# Altium schematic sheet 12 HDMI RX.SchDoc
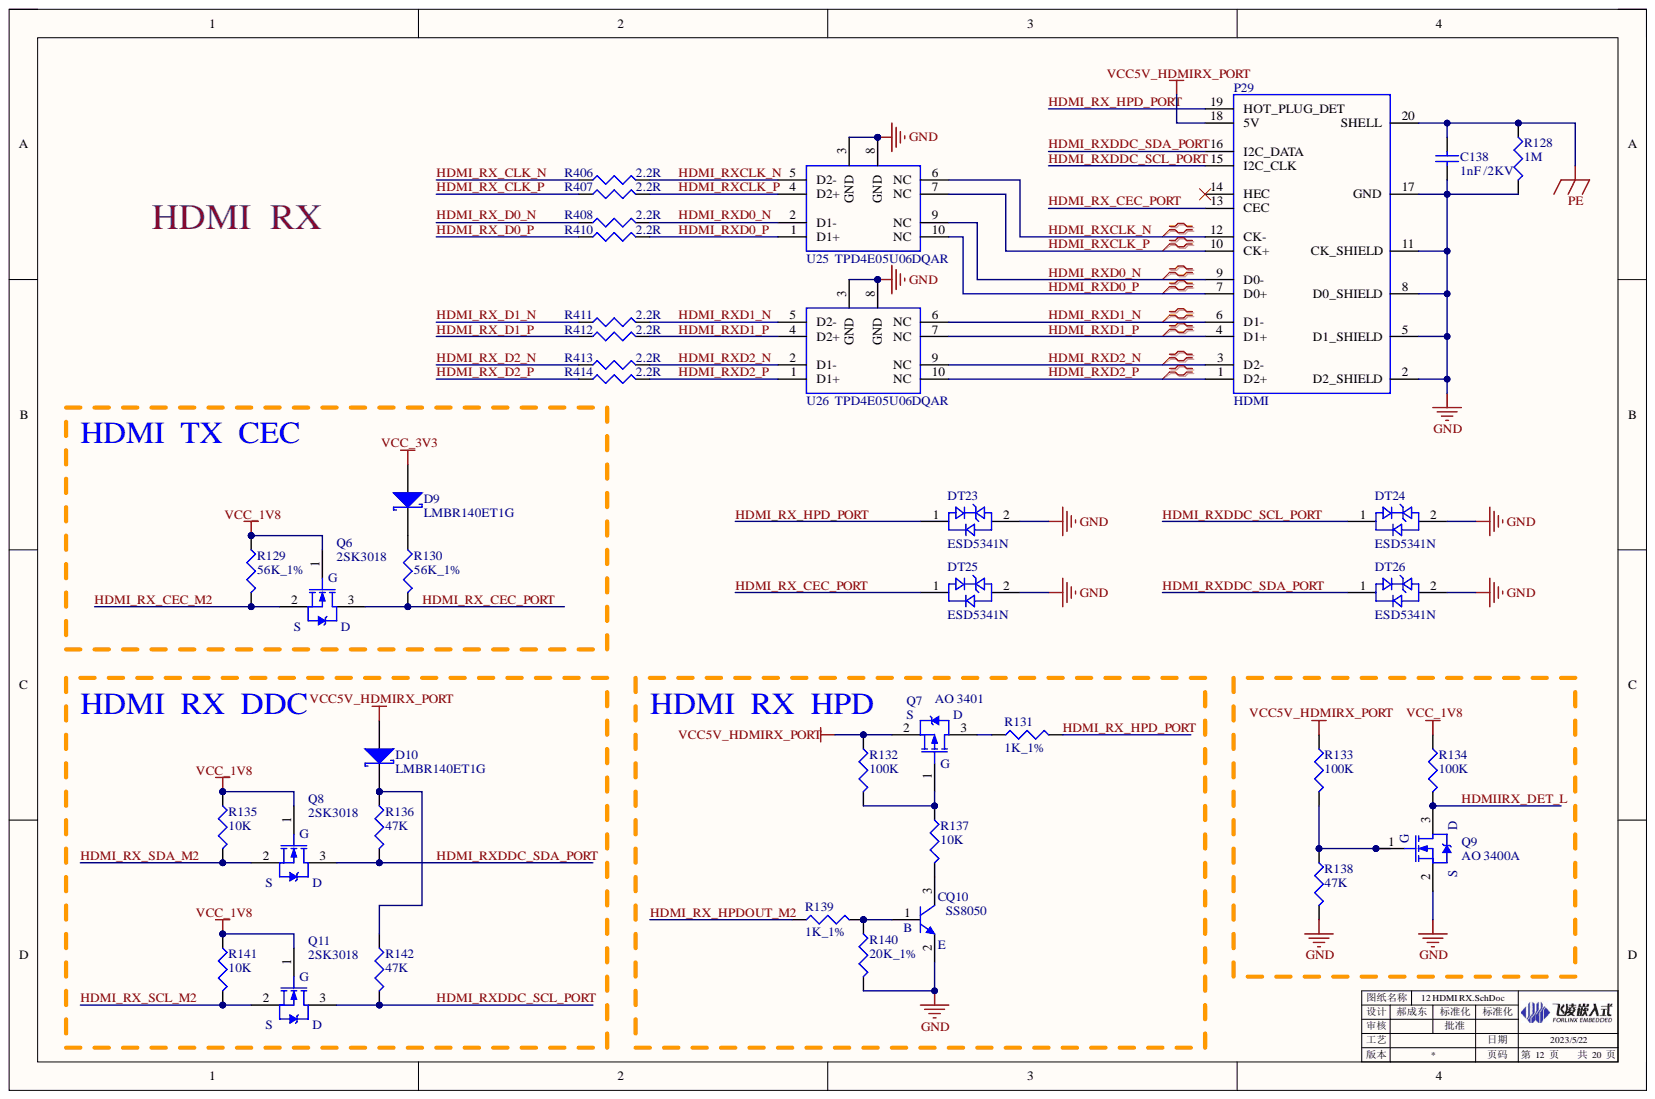
[diagram p0: 12 HDMI RX.SchDoc]

## Parts
C138  1nF /2KV  [1206C]
D9  LMBR140ET1G  [SOD_323]
D10  LMBR140ET1G  [SOD_323]
DT23  ESD5341N  [ESD5341N]
DT24  ESD5341N  [ESD5341N]
DT25  ESD5341N  [ESD5341N]
DT26  ESD5341N  [ESD5341N]
P29  HDMI  [HDMI]
Q6  2SK3018  [SOT323]
Q7  AO 3401  [SOT_233_W]
Q8  2SK3018  [SOT323]
Q9  AO 3400A  [SOT_233_W]
Q10  SS8050  [SOT_233_N]
Q11  2SK3018  [SOT323]
R128  1M  [1206R]
R129  56K_1%  [0402R]
R130  56K_1%  [0402R]
R131  1K_1%  [0402R]
R132  100K  [0402R]
R133  100K  [0402R]
R134  100K  [0402R]
R135  10K  [0402R]
R136  47K  [0402R]
R137  10K  [0402R]
R138  47K  [0402R]
R139  1K_1%  [0402R]
R140  20K_1%  [0402R]
R141  10K  [0402R]
R142  47K  [0402R]
R406  2.2R  [0201R]
R407  2.2R  [0201R]
R408  2.2R  [0201R]
R410  2.2R  [0201R]
R411  2.2R  [0201R]
R412  2.2R  [0201R]
R413  2.2R  [0201R]
R414  2.2R  [0201R]
U25  TPD4E05U06DQAR  [USON-10]
U26  TPD4E05U06DQAR  [USON-10]

## Nets
GND: C138.1 DT23.2 DT24.2 DT25.2 DT26.2 P29.2(D2_SHIELD) P29.5(D1_SHIELD) P29.8(D0_SHIELD) P29.11(CK_SHIELD) P29.17(GND) Q9.2 Q10.2 R128.2 R138.2 R140.2 U25.3(GND) U25.8(GND) U26.3(GND) U26.8(GND)
PE: C138.2 P29.20(SHELL) R128.1
VCC5V_HDMIRX_PORT: D10.1 P29.18(5V) Q7.2 R132.1 R133.1
VCC_1V8: Q6.1 Q8.1 Q11.1 R129.1 R134.1 R135.1 R141.1
VCC_3V3: D9.1
HDMI_RX_CEC_M2: Q6.2 R129.2
HDMI_RX_CEC_PORT: DT25.1 P29.13(CEC) Q6.3 R130.2
HDMI_RX_CLK_N: R406.1
HDMI_RX_CLK_P: R407.1
HDMI_RX_D0_N: R408.1
HDMI_RX_D0_P: R410.1
HDMI_RX_D1_N: R411.1
HDMI_RX_D1_P: R412.1
HDMI_RX_D2_N: R413.1
HDMI_RX_D2_P: R414.1
HDMI_RX_HPD_PORT: DT23.1 P29.19(HOT_PLUG_DET) R131.2
HDMI_RX_HPDOUT_M2: R139.1
HDMI_RX_SCL_M2: Q11.2 R141.2
HDMI_RX_SDA_M2: Q8.2 R135.2
HDMI_RXCLK_N: P29.12(CK-) R406.2 U25.5(D2-) U25.6(NC)
HDMI_RXCLK_P: P29.10(CK+) R407.2 U25.4(D2+) U25.7(NC)
HDMI_RXD0_N: P29.9(D0-) R408.2 U25.2(D1-) U25.9(NC)
HDMI_RXD0_P: P29.7(D0+) R410.2 U25.1(D1+) U25.10(NC)
HDMI_RXD1_N: P29.6(D1-) R411.2 U26.5(D2-) U26.6(NC)
HDMI_RXD1_P: P29.4(D1+) R412.2 U26.4(D2+) U26.7(NC)
HDMI_RXD2_N: P29.3(D2-) R413.2 U26.2(D1-) U26.9(NC)
HDMI_RXD2_P: P29.1(D2+) R414.2 U26.1(D1+) U26.10(NC)
HDMI_RXDDC_SCL_PORT: DT24.1 P29.15(I2C_CLK) Q11.3 R142.2
HDMI_RXDDC_SDA_PORT: DT26.1 P29.16(I2C_DATA) Q8.3 R136.2
HDMIIRX_DET_L: Q9.3 R134.2
NetD9_2: D9.2 R130.1
NetD10_2: D10.2 R136.1 R142.1
NetQ7_1: Q7.1 R132.2 R137.1
NetQ7_3: Q7.3 R131.1
NetQ9_1: Q9.1 R133.2 R138.1
NetQ10_1: Q10.1 R139.2 R140.1
NetQ10_3: Q10.3 R137.2

Not connected: P29 14(HEC)

## Library data of the parts
C138 = 1110213001: Database Table Name: VALID$; 存货名称: 贴装高压电容; 安全库存: 116; 序号: 770; 旧编码: 010205013; 旧规格型号: 1206 1nF 2KV ±10％; 规格型号: 1206,1nF,2KV,±10％; Component Kind: Standard; LatestRevisionDate: 17-Jul-2002; LatestRevisionNote: Re-released for DXP Platform.; Library Name: Database_AD Library.DbLib; PackageDescription: Radial Cap, Thru-Hole; 2 Leads; 0.3 in Pin Spacing; PackageReference: RAD-0.3; Published: 8-Jun-2000; Signal Integrity: Cap; Simulation: CAP; Value: 1nF /2KV; 存货编码: 1110213001; Publisher: Altium Limited
D9 D10 = 1110501064: Database Table Name: VALID$; 存货名称: 肖特基二极管; 规格型号: LMBR140ET1G,SOD323; Code_JEDEC: DO-214-AA; Component Kind: Standard; LatestRevisionDate: 17-Jul-2002; LatestRevisionNote: Re-released for DXP Platform.; Library Name: Database_AD Library.DbLib; PackageDescription: DO-214-AA/SMB; 2 C-Bend Leads; Body 5.3 x 3.6 mm, inc. leads (LxW); PackageReference: SMB; Published: 8-Jun-2000; Signal Integrity: D Schottky; Simulation: SKYDIODE; Value: LMBR140ET1G; 存货编码: 1110501064; Publisher: Altium Limited
P29 = 1120202041: Database Table Name: VALID$; 存货名称: 插装HDMI座; 序号: 1427; 描述栏信息: FCI生产编号：10029449,001RLF  ，与1120202030通用; 规格型号: A型（替代1120202030）; Component Kind: Standard; Library Name: Database_AD Library.DbLib; Value: HDMI; 存货编码: 1120202041
Q6 Q8 Q11 = 1110505016: Database Table Name: VALID$; Note: Pin 2 is electrically connected to pin 4.; 存货名称: 场效应管; 序号: 2672; 规格型号: 2SK3018,SOT-323,N沟道; Component Kind: Standard; DatasheetVersion: PD-9.814; LatestRevisionDate: 17-Jul-2002; LatestRevisionNote: Re-released for DXP Platform.; Library Name: Database_AD Library.DbLib; PackageDescription: TO, Thru-Hole, Vertical, Heatsink Mounted; 3 In-Line Leads; Pitch 2.54 mm; PackageReference: TO-220AB; PackageVersion: Feb-1997; Published: 8-Jun-2000; Simulation: IRF1010; VALUE: 2SK3018; 存货编码: 1110505016; Publisher: Altium Limited
Q7 = 1110505019: Database Table Name: VALID$; Note: Pin 2 is electrically connected to pin 4.; 存货名称: 场效应管; 序号: 2675; 规格型号: AO3401,SOT-23-3,P沟道; Component Kind: Standard; ComponentLink1Description: Manufacturer Link; ComponentLink1URL: http://www.irf.com/; DatasheetVersion: PD-9.814; LatestRevisionDate: 17-Jul-2002; LatestRevisionNote: Re-released for DXP Platform.; Library Name: Database_AD Library.DbLib; PackageDescription: TO, Thru-Hole, Vertical, Heatsink Mounted; 3 In-Line Leads; Pitch 2.54 mm; PackageReference: TO-220AB; PackageVersion: Feb-1997; Published: 8-Jun-2000; Simulation: IRF1010; VALUE: AO 3401; 存货编码: 1110505019; Publisher: Altium Limited
Q9 = 1110505027: Database Table Name: VALID$; Note: Pin 2 is electrically connected to pin 4.; 存货名称: 场效应管; 描述栏信息: 替换1110505011; 规格型号: AO3400A,SOT23,N沟道; Component Kind: Standard; DatasheetVersion: PD-9.814; LatestRevisionDate: 17-Jul-2002; LatestRevisionNote: Re-released for DXP Platform.; Library Name: Database_AD Library.DbLib; PackageDescription: TO, Thru-Hole, Vertical, Heatsink Mounted; 3 In-Line Leads; Pitch 2.54 mm; PackageReference: TO-220AB; PackageVersion: Feb-1997; Published: 8-Jun-2000; Simulation: IRF1010; VALUE: AO 3400A; 存货编码: 1110505027; Publisher: Altium Limited
Q10 = 1110502011: Database Table Name: VALID$; 存货名称: 三极管; 序号: 2582; 规格型号: SS8050,SOT-23; Code_JEDEC: TO-226-AA; Component Kind: Standard; LatestRevisionDate: 17-Jul-2002; LatestRevisionNote: Re-released for DXP Platform.; Library Name: Database_AD Library.DbLib; PackageDescription: TO, Flat Index; 3 In-Line, Axial Leads; Body Dia. 4.8mm; Leads 0.55 x 0.51 mm (max); PackageReference: TO-226-AA; Published: 8-Jun-2000; Signal Integrity: NPN; Simulation: NPN; VALUE: SS8050; 存货编码: 1110502011; Publisher: Altium Limited
R128 = 1110105003: Database Table Name: VALID$; 存货名称: 贴装电阻; 序号: 501; 规格型号: 1206,1M,±5％; Component Kind: Standard; Library Name: Database_AD Library.DbLib; Signal Integrity: Res; Simulation: RESISTOR; Value: 1M; 存货编码: 1110105003
R129 R130 = 1110102163: Database Table Name: VALID$; 存货名称: 贴装电阻; 规格型号: 0402,56K,±1％; Component Kind: Standard; Library Name: Database_AD Library.DbLib; Signal Integrity: Res; Simulation: RESISTOR; Value: 56K_1%; 存货编码: 1110102163
R131 R139 = 1110102011: Database Table Name: VALID$; 存货名称: 贴装电阻; 序号: 21; 规格型号: 0402,1K,±1％; Component Kind: Standard; Library Name: Database_AD Library.DbLib; Signal Integrity: Res; Simulation: RESISTOR; Value: 1K_1%; 存货编码: 1110102011
R132-R134 = 1110102004: Database Table Name: VALID$; 存货名称: 贴装电阻; 序号: 14; 规格型号: 0402,100K,±5％; Component Kind: Standard; Library Name: Database_AD Library.DbLib; Signal Integrity: Res; Simulation: RESISTOR; Value: 100K; 存货编码: 1110102004
R135 R137 R141 = 1110102007: Database Table Name: VALID$; 存货名称: 贴装电阻; 序号: 17; 规格型号: 0402,10K,±5％; Component Kind: Standard; Library Name: Database_AD Library.DbLib; Signal Integrity: Res; Simulation: RESISTOR; Value: 10K; 存货编码: 1110102007
R136 R138 R142 = 1110102040: Database Table Name: VALID$; 存货名称: 贴装电阻; 序号: 53; 规格型号: 0402,47K,±5％; Component Kind: Standard; Library Name: Database_AD Library.DbLib; Signal Integrity: Res; Simulation: RESISTOR; Value: 47K; 存货编码: 1110102040
R140 = 1110102074: Database Table Name: VALID$; 存货名称: 贴装电阻; 序号: 106; 规格型号: 0402,20K,±1％; Component Kind: Standard; Library Name: Database_AD Library.DbLib; Signal Integrity: Res; Simulation: RESISTOR; Value: 20K_1%; 存货编码: 1110102074
R406-R408 R410-R414 = 1110101001: Database Table Name: VALID$; 存货名称: 贴装电阻; 序号: 556; 规格型号: 0201,0Ω,±5%; Component Kind: Standard; Library Name: Database_AD Library.DbLib; Signal Integrity: Res; Simulation: RESISTOR; Value: 2.2R; 存货编码: 1110101001
U25 U26 = 1110503037: Database Table Name: VALID$; 存货名称: TVS管; 规格型号: TPD4E05U06DQAR,USON; Component Kind: Standard; Library Name: Database_AD Library.DbLib; Value: TPD4E05U06DQAR; 存货编码: 1110503037
DT23-DT26 = ESD5341N: Component Kind: Standard; Library Name: OK3588-C V1.1.SchLib
